# Altium schematic sheet Sheet1.SchDoc
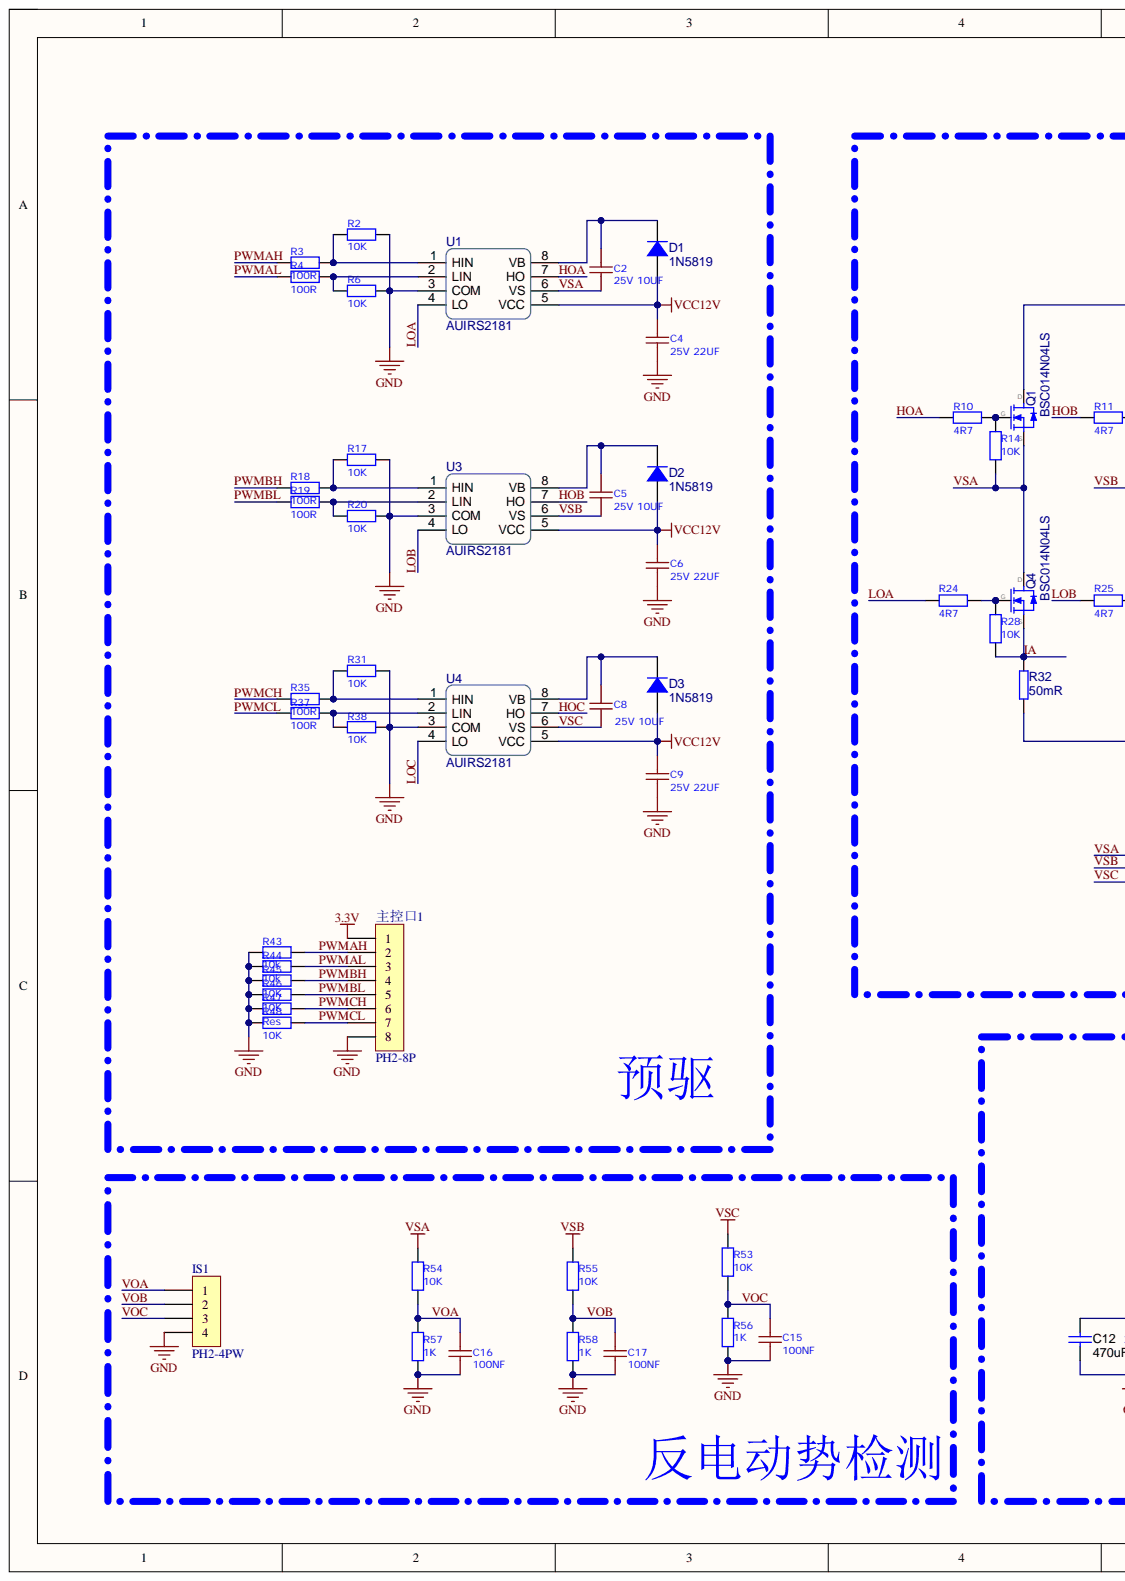
[diagram p0: Sheet1.SchDoc, left]
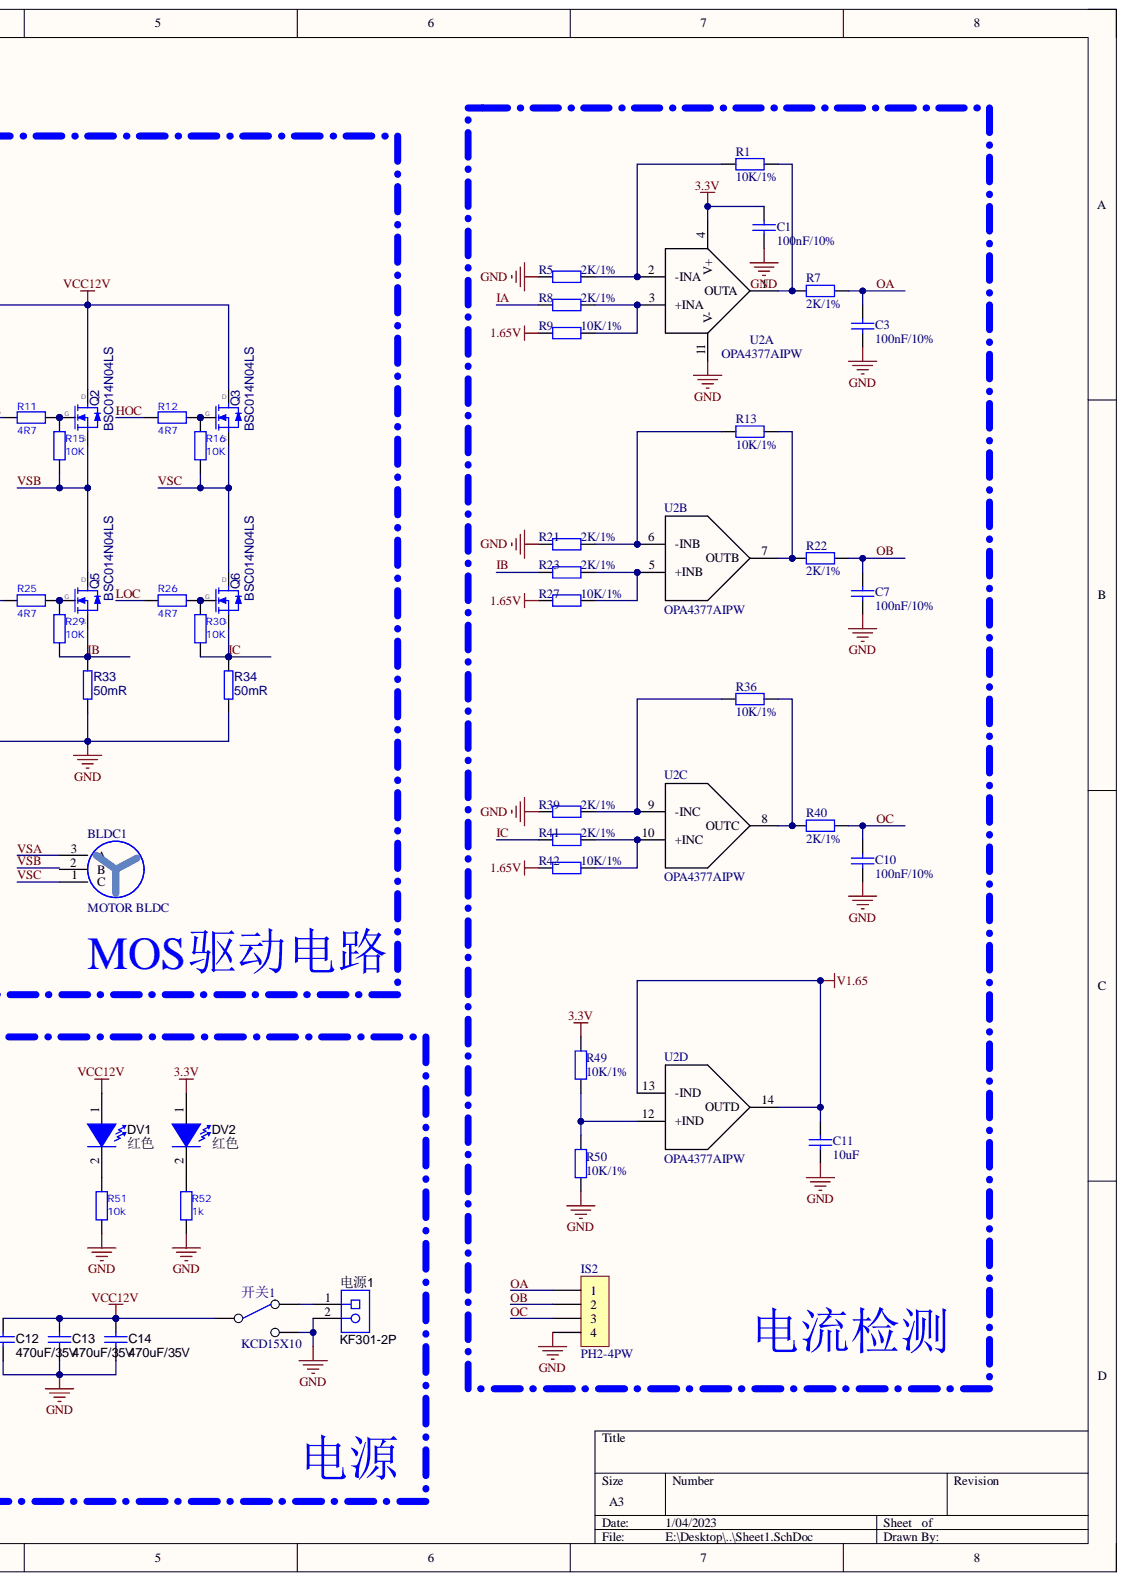
[diagram p1: Sheet1.SchDoc, right]

## Parts
BLDC1  MOTOR BLDC  [LQ_PIN3]
C1  100nF/10%  [CAP0603]
C2  25V 10UF  [CAP0603]
C3  100nF/10%  [LQ_C0603]
C4  25V 22UF  [CAP0603]
C5  25V 10UF  [CAP0603]
C6  25V 22UF  [CAP0603]
C7  100nF/10%  [LQ_R0603]
C8  25V 10UF  [CAP0603]
C9  25V 22UF  [CAP0603]
C10  100nF/10%  [RES0603]
C11  10uF  [RES0603]
C12  470uF/35V  [LQ_C1210]
C13  470uF/35V  [LQ_C1210]
C14  470uF/35V  [LQ_C1210]
C15  100NF  [CAP0603]
C16  100NF  [CAP0603]
C17  100NF  [CAP0603]
D1  1N5819  [SOD-123-L]
D2  1N5819  [SOD-123-L]
D3  1N5819  [SOD-123-L]
DV1  红色  [LQ_LED0603R]
DV2  红色  [LQ_LED0603R]
IS1  PH2-4PW  [LQ_PH2.0-WI-4P]
IS2  PH2-4PW  [LQ_PH2.0-WI-4P]
Q1  BSC014N04LS  [TDSON-8]
Q2  BSC014N04LS  [TDSON-8]
Q3  BSC014N04LS  [TDSON-8]
Q4  BSC014N04LS  [TDSON-8]
Q5  BSC014N04LS  [TDSON-8]
Q6  BSC014N04LS  [TDSON-8]
R1  10K/1%  [RES0603]
R2  10K  [RES0603]
R3  100R  [RES0603]
R4  100R  [RES0603]
R5  2K/1%  [LQ_R0603]
R6  10K  [RES0603]
R7  2K/1%  [LQ_R0603]
R8  2K/1%  [LQ_R0603]
R9  10K/1%  [LQ_R0603]
R10  4R7  [RES0603]
R11  4R7  [RES0603]
R12  4R7  [RES0603]
R13  10K/1%  [LQ_R0603]
R14  10K  [RES0603]
R15  10K  [RES0603]
R16  10K  [RES0603]
R17  10K  [RES0603]
R18  100R  [RES0603]
R19  100R  [RES0603]
R20  10K  [RES0603]
R21  2K/1%  [LQ_R0603]
R22  2K/1%  [LQ_R0603]
R23  2K/1%  [LQ_R0603]
R24  4R7  [RES0603]
R25  4R7  [RES0603]
R26  4R7  [RES0603]
R27  10K/1%  [LQ_R0603]
R28  10K  [RES0603]
R29  10K  [RES0603]
R30  10K  [RES0603]
R31  10K  [RES0603]
R32  value 50mR  [CAP0805]  (hidden comment: 50mR ±1%)
R33  value 50mR  [RES0805]  (hidden comment: 50mR ±1%)
R34  value 50mR  [RES0805]  (hidden comment: 50mR ±1%)
R35  100R  [RES0603]
R36  10K/1%  [LQ_R0603]
R37  100R  [RES0603]
R38  10K  [RES0603]
R39  2K/1%  [LQ_R0603]
R40  2K/1%  [LQ_R0603]
R41  2K/1%  [LQ_R0603]
R42  10K/1%  [LQ_R0603]
R43  10k  [RES0603]
R44  10k  [RES0603]
R45  10K  [RES0603]
R46  10K  [RES0603]
R47  Res  [RES0603]
R48  10K  [RES0603]
R49  10K/1%  [LQ_R0603]
R50  10K/1%  [LQ_R0603]
R51  10k  [RES0603]
R52  1k  [RES0603]
R53  10K  [RES0603]
R54  10K  [RES0603]
R55  10K  [RES0603]
R56  1K  [RES0603]
R57  1K  [RES0603]
R58  1K  [CAP0603]
U1  AUIRS2181  [SOP-8]
U2  OPA4377AIPW  [LQ_TSSOP14-OPA4377AIPW]  (parts A,B,C,D)
U3  AUIRS2181  [SOP-8]
U4  AUIRS2181  [SOP-8]
主控口1  PH2-8P  [LQ_PH2-8PW]
开关1  KCD15X10  [LQ_KCD1-101 117S]
电源1  KF301-2P  [LQ_PIN2]

## Nets
1.65V: R9.1 R27.1 R42.1
3.3V: C1.2 DV2.1 R49.2 U2.4(V+) 主控口1.1
GND: C1.1 C3.1 C4.1 C6.1 C7.1 C9.1 C10.1 C11.1 C12.2 C13.2 C14.2 C15.1 C16.1 C17.1 IS1.4 IS2.4 R2.1 R5.1 R6.1 R17.1 R20.1 R21.1 R31.1 R32.1 R33.1 R34.1 R38.1 R39.1 R43.2 R44.2 R45.2 R46.2 R47.2 R48.2 R50.1 R51.2 R52.2 R56.2 R57.2 R58.2 U1.3(COM) U2.11(V-) U3.3(COM) U4.3(COM) 主控口1.8 开关1.3 电源1.2
V1.65: C11.2 U2.13(-IND) U2.14(OUTD)
VCC12V: C4.2 C6.2 C9.2 C12.1 C13.1 C14.1 D1.A D2.A D3.A DV1.1 Q1.1 Q2.1 Q3.1 U1.5(VCC) U3.5(VCC) U4.5(VCC) 开关1.1
HOA: R10.2 U1.7(HO)
HOB: R11.2 U3.7(HO)
HOC: R12.2 U4.7(HO)
IA: Q4.3 R8.1 R28.2 R32.2
IB: Q5.3 R23.1 R29.2 R33.2
IC: Q6.3 R30.2 R34.2 R41.1
LOA: R24.2 U1.4(LO)
LOB: R25.2 U3.4(LO)
LOC: R26.2 U4.4(LO)
OA: C3.2 IS2.1 R7.2
OB: C7.2 IS2.2 R22.2
OC: C10.2 IS2.3 R40.2
PWMAH: R3.2 R43.1 主控口1.2
PWMAL: R4.2 R44.1 主控口1.3
PWMBH: R18.2 R45.1 主控口1.4
PWMBL: R19.2 R46.1 主控口1.5
PWMCH: R35.2 R47.1 主控口1.6
PWMCL: R37.2 R48.1 主控口1.7
VOA: C16.2 IS1.1 R54.2 R57.1
VOB: C17.2 IS1.2 R55.2 R58.1
VOC: C15.2 IS1.3 R53.2 R56.1
VSA: BLDC1.3 C2.1 Q1.3 Q4.1 R14.2 R54.1 U1.6(VS)
VSB: C5.1 Q2.3 Q5.1 R15.2 R55.1 U3.6(VS)
VSC: BLDC1.1 C8.1 Q3.3 Q6.1 R16.2 R53.1 U4.6(VS)
NetC2_2: C2.2 D1.K U1.8(VB)
NetC5_2: C5.2 D2.K U3.8(VB)
NetC8_2: C8.2 D3.K U4.8(VB)
NetDV1_2: DV1.2 R51.1
NetDV2_2: DV2.2 R52.1
NetQ1_2: Q1.2 R10.1 R14.1
NetQ2_2: Q2.2 R11.1 R15.1
NetQ3_2: Q3.2 R12.1 R16.1
NetQ4_2: Q4.2 R24.1 R28.1
NetQ5_2: Q5.2 R25.1 R29.1
NetQ6_2: Q6.2 R26.1 R30.1
NetR1_1: R1.1 R5.2 U2.2(-INA)
NetR1_2: R1.2 R7.1 U2.1(OUTA)
NetR2_2: R2.2 R3.1 U1.1(HIN)
NetR4_1: R4.1 R6.2 U1.2(LIN)
NetR8_2: R8.2 R9.2 U2.3(+INA)
NetR13_1: R13.1 R21.2 U2.6(-INB)
NetR13_2: R13.2 R22.1 U2.7(OUTB)
NetR17_2: R17.2 R18.1 U3.1(HIN)
NetR19_1: R19.1 R20.2 U3.2(LIN)
NetR23_2: R23.2 R27.2 U2.5(+INB)
NetR31_2: R31.2 R35.1 U4.1(HIN)
NetR36_1: R36.1 R39.2 U2.9(-INC)
NetR36_2: R36.2 R40.1 U2.8(OUTC)
NetR37_1: R37.1 R38.2 U4.2(LIN)
NetR41_2: R41.2 R42.2 U2.10(+INC)
NetR49_1: R49.1 R50.2 U2.12(+IND)
Net开关1_2: 开关1.2 电源1.1

Not connected: BLDC1 2

## Library data of the parts
C1 C3 C7 C10 C11 = Cap: Capacitor; Value: 2.2nF; Component Kind: Standard; LatestRevisionDate: 17-Jul-2002; LatestRevisionNote: Re-released for DXP Platform.; Library Name: Motor.SchLib; PackageDescription: Radial Cap, Thru-Hole; 2 Leads; 0.3 in Pin Spacing; PackageReference: RAD-0.3; Published: 8-Jun-2000; Signal Integrity: Cap; Simulation: CAP; Publisher: Altium Limited
C2 C4-C6 C8 C9 C15-C17 = cap: Component Kind: Standard; Library Name: 电阻电容电感.IntLib
C12-C14 = GRM21BR71H105KA12L: Manufacturer: MuRata; Cap_Case: 0805; Cap_F: 0.000001; CompType: Capacitor; Polarized: N; TaMax_C: 125; TaMin_C: -55; TempCo: X7R; Tolerance_%: 10; U/M: EA; Value: 1uF; Value1: Unknown; Value2: 50V; ValueDisplayed: 1µF; VDC_V: 50; Component Kind: Standard; ComponentLink1Description: Datasheet link; ComponentLink1URL: http://search.murata.co.jp/Ceramy/PnsearchViewAction.do?HnOFG=ON&HnNFG=ON&SEL_CNT=50&sLang=en&sKey=GRM21BR71H105KA12L&x=9&y=9&search=startwith; LatestRevisionDate: 2013-09-06; Library Name: LQ2304BLDCV2.SCHLIB; MountType: SMT; PackageReference: 0805; PartNumber: GRM21BR71H105KA12L; Published: 2008-06-19; RoHS: Y; Signal Integrity: Cap; Simulation: Cap; Supplier 1: Digi-Key; Supplier 2: Mouser; Supplier 3: Farnell; Supplier Part Number 1: 490-4736-1-ND; Supplier Part Number 2: 81-GRM21BR71H105KA2L; Supplier Part Number 3: 1735541; SymbolVersion: 2008-07-09; Technology: Ceramic; Publisher: Texas Instruments
D1-D3 = Diode: 普通二极管 Diode; Imax: 500mA; Component Kind: Standard; Price(RMB): 0.000; Published: 8-Jun-2000; Revision: July-2002: Re-released for DXP Platform.; Library Name: 无刷电调-Infineon-CCU6V1.4原理图库.SCHLIB; Publisher: Altium Limited
DV1 DV2 = LTST-C171GKT: Manufacturer: Lite-On; CompType: LED; Io_A: 20; U/M: EA; Value: Green; Value1: W; Value2: 2.1V; Vf_V: 2.1; Color: green; Component Kind: Standard; ComponentLink1Description: Datasheet link; ComponentLink1URL: https://sps06.itg.ti.com/sites/KMS/crossdivteams/altium/docs/ComponentDatasheets/LTST-C171GKT.pdf; LatestRevisionDate: 2013-09-07; Library Name: LQ2304BLDCV2.SCHLIB; MountType: SMT; PackageReference: LED_0805; PartNumber: LTST-C171GKT; Published: 2008-07-11; RoHS: Y; Signal Integrity: Diode; Supplier 1: Digi-Key; Supplier 2: Mouser; Supplier Part Number 1: 160-1423-1-ND; Supplier Part Number 2: 859-LTST-C171GKT; SymbolVersion: 2008-12-08; Type: AlInGaP; Publisher: Texas Instruments
IS1 IS2 = CON4: Connector; Component Kind: Standard; Library Name: LQ2304BLDCV2.SCHLIB
Q1-Q6 = BSC014N04LS: 英飞凌 N沟 MOS; Component Kind: Standard; Library Name: 无刷电调-Infineon-CCU6V1.4原理图库.SCHLIB
R1 R5 R7-R9 R13 R21-R23 R27 R36 R39-R42 R49 R50 = Res2: Resistor; Value: 4.7k; Component Kind: Standard; LatestRevisionDate: 17-Jul-2002; LatestRevisionNote: Re-released for DXP Platform.; Library Name: Motor.SchLib; PackageDescription: Axial Device, Thru-Hole; 2 Leads; 0.4 in Pin Spacing; PackageReference: AXIAL-0.4; Published: 8-Jun-2000; Signal Integrity: Res; Simulation: RESISTOR; Publisher: Altium Limited
R2-R4 R6 R10-R12 R14-R20 R24-R26 R28-R31 R35 R37 R38 R43-R46 R48 R51-R58 = Res: Component Kind: Standard; Library Name: 电阻电容电感.IntLib
R32-R34 = Res-Chip_19: 贴片电阻; Component Kind: Standard; Published: 8-Jun-2000; Revision: July-2002: Re-released for DXP Platform.; Tolerance: ±1%; Library Name: 无刷电调-Infineon-CCU6V1.4原理图库.SCHLIB
主控口1 = CON8: Connector; Component Kind: Standard; Library Name: LQ2304BLDCV2.SCHLIB
开关1 = SW SPDT: Component Kind: Standard; Library Name: LQ2304BLDCV2.SCHLIB
电源1 = 691 101 710 002: Manufacturer: Wurth Elektronik eiSos; CompType: Terminal Block; SymbolCreator: a0412235; U/M: EA; Component Kind: Standard; ComponentLink1Description: Datasheet Link; ComponentLink1URL: http://katalog.we-online.de/em/datasheet/6911017100xx.pdf; LatestRevisionDate: 2015-02-03; Library Name: LQ2304BLDCV2.SCHLIB; MountType: TH; PackageReference: Terminal Block, 5 mm, 2x1, TH; PartNumber: 691 101 710 002; RoHS: Y; Supplier 1: Digi-Key; Supplier 2: Mouser; Supplier Part Number 1: 732-2026-ND; Supplier Part Number 2: 710-691101710002; SymbolVersion: 2013-09-04; Publisher: Texas Instruments
BLDC1 = MOTOR BLDC: Component Kind: Standard; Library Name: LQ2304BLDCV2.SCHLIB
R47 = Res: Component Kind: Standard; Library Name: 电阻电容电感.IntLib
U1 U3 U4 = AUIRS2181: Component Kind: Standard; Description: AUIRS2181; Library Name: 无刷电调-Infineon-CCU6V1.4原理图库.SCHLIB
U2 = OPA4377AIPW: Component Kind: Standard; Library Name: Motor.SchLib
